# Altium schematic sheet MISC.SchDoc(MISC)
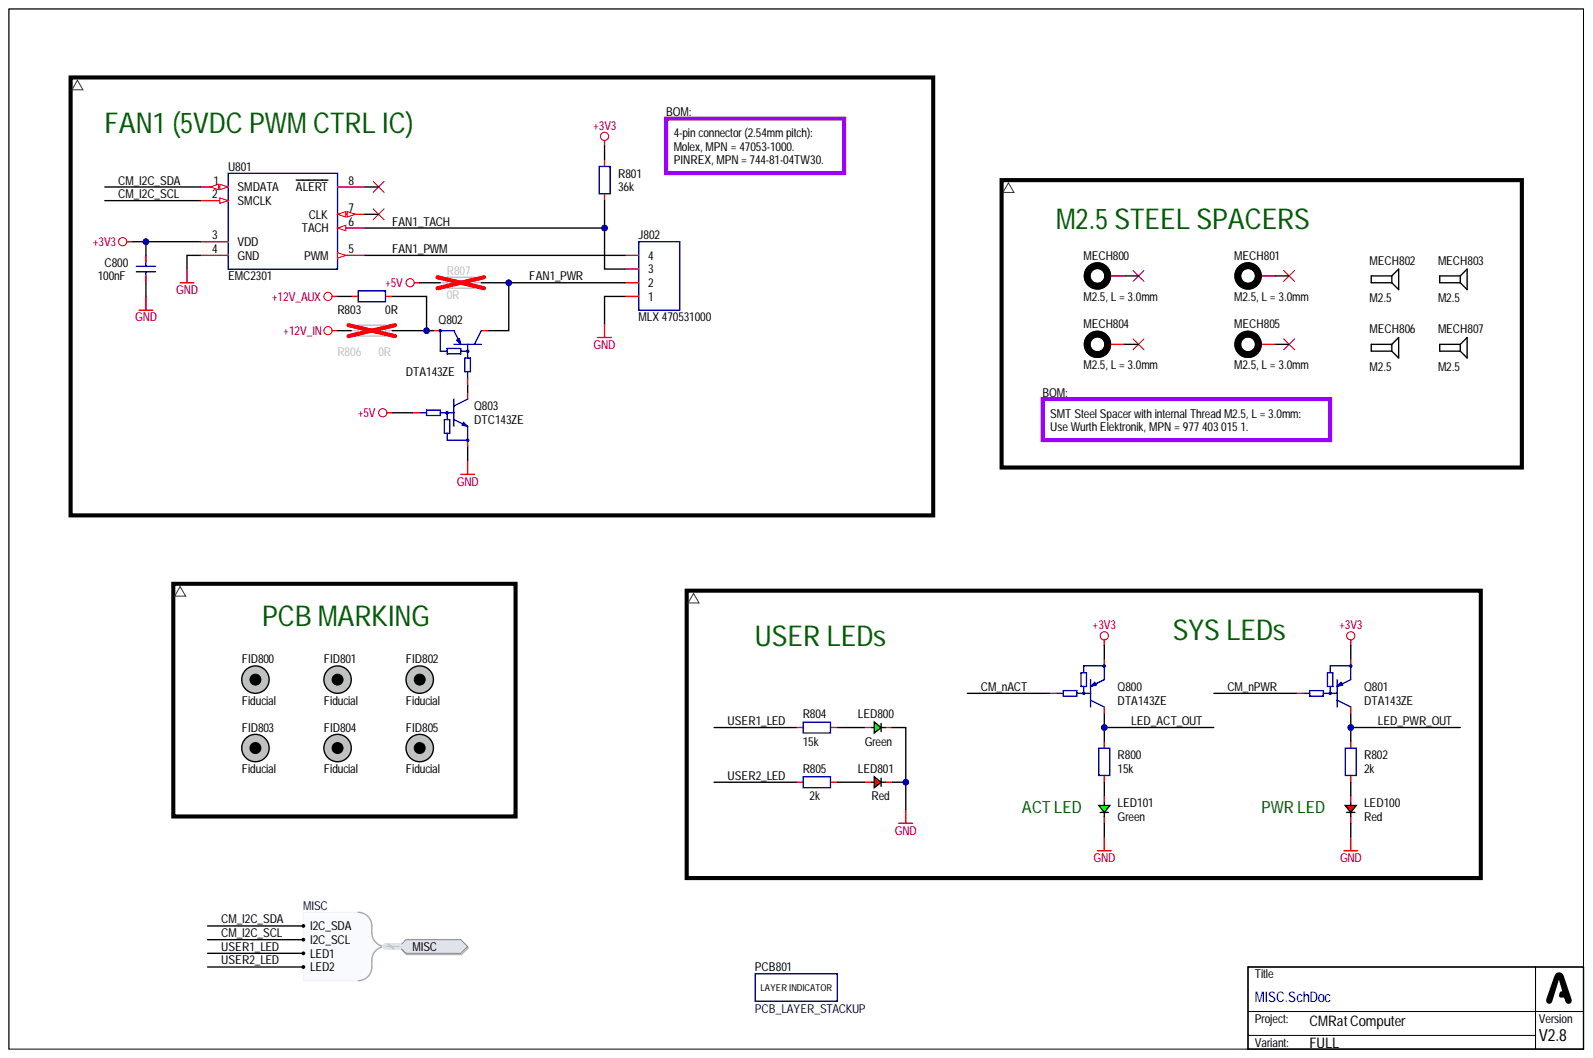
[diagram p0: MISC.SchDoc]

## Parts
C800  100nF  [CAP_0603_R]
FID800  Fiducial  [PCB_FID_RND_100x300]  (no BOM)
FID801  Fiducial  [PCB_FID_RND_100x300]  (no BOM)
FID802  Fiducial  [PCB_FID_RND_100x300]  (no BOM)
FID803  Fiducial  [PCB_FID_RND_100x300]  (no BOM)
FID804  Fiducial  [PCB_FID_RND_100x300]  (no BOM)
FID805  Fiducial  [PCB_FID_RND_100x300]  (no BOM)
J802  MLX 470531000  MPN: 470531000  [CON_MLX_470531000]
LED100  Red  Component Type: LED  [LED_0805_RED_R]
LED101  Green  Component Type: LED  [LED_0805_GRN_R]
LED800  Green  [LED_0805_GRN_R]
LED801  Red  [LED_0805_RED_R]
MECH800  M2.5, L = 3.0mm  [PCB_WRT_9774030151]
MECH801  M2.5, L = 3.0mm  [PCB_WRT_9774030151]
MECH802  M2.5  (mechanical)
MECH803  M2.5  (mechanical)
MECH804  M2.5, L = 3.0mm  [PCB_WRT_9774030151]
MECH805  M2.5, L = 3.0mm  [PCB_WRT_9774030151]
MECH806  M2.5  (mechanical)
MECH807  M2.5  (mechanical)
PCB801  PCB_LAYER_STACKUP  [PCB_STACKUP-4L_A2]  (no BOM)
Q800  DTA143ZE  [PKG_SOT523_SC75_SOT416_P100_R]
Q801  DTA143ZE  [PKG_SOT523_SC75_SOT416_P100_R]
Q802  DTA143ZE  [PKG_SOT523_SC75_SOT416_P100_R]
Q803  DTC143ZE  [PKG_SOT523_SC75_SOT416_P100_R]
R800  15k  [RES_0603_R]
R801  36k  [RES_0603_R]
R802  2k  [RES_0603_R]
R803  0R  [RES_0603_R]
R804  15k  [RES_0603_R]
R805  2k  [RES_0603_R]
R806  0R  [RES_0603_R]
R807  0R  [RES_0603_R]
TB800  =Value  (no BOM)
U801  EMC2301  [ICC_TSSOP8_300X300X110P65]

## Ports
MISC, MISC.I2C_SCL, MISC.I2C_SDA, MISC.LED1, MISC.LED2

## Nets
+3V3: C800.2 Q800.2 Q801.2 R801.2 U801.3(VDD)
+5V: Q803.1 R807.2
+12V_AUX: R803.2
+12V_IN: R806.2
GND: C800.1 J802.1 LED100.1 LED101.1 LED800.1 LED801.1 Q803.2 U801.4(GND)
CM_I2C_SCL: U801.2(SMCLK) ; port MISC
CM_I2C_SDA: U801.1(SMDATA) ; port MISC
CM_nACT: Q800.1
CM_nPWR: Q801.1
FAN1_PWM: J802.4 U801.5(PWM)
FAN1_PWR: J802.2 Q802.3 R807.1
FAN1_TACH: J802.3 R801.1 U801.6(TACH)
LED_ACT_OUT: Q800.3 R800.2
LED_PWR_OUT: Q801.3 R802.2
USER1_LED: R804.1 ; port MISC
USER2_LED: R805.1 ; port MISC
NetLED100_2: LED100.2 R802.1
NetLED101_2: LED101.2 R800.1
NetLED800_2: LED800.2 R804.2
NetLED801_2: LED801.2 R805.2
NetQ802_1: Q802.1 Q803.3
NetQ802_2: Q802.2 R803.1 R806.1

Not connected: MECH800 1; MECH801 1; MECH804 1; MECH805 1; U801 7(CLK) 8(ALERT)

## Library data of the parts
C800 = CAP-0008: Capacitor; MLCC; 100nF; 10V X5R; Manufacturer: YAGEO; MPN: CC0603KRX7R9BB104; Database Table Name: CAPACITOR$; Package (Case): 0603; Component Kind: Standard; Component Subtype: MLCC; Component Type: Capacitor; Mounting Type: SMD; Value: 100nF; Library Name: MirFol_db_local.DbLib
FID800-FID805 = PCB_FID_RND: PCB Marking; Fiducial; Round;; Component Type: Fiducial; Value: Fiducial; Library Name: MirFol_lib.SchLib
J802 = CON_1X04_COL: Connector; 1 Row; 470531000; Manufacturer: Molex; Component Subtype: Pin header; Package (Case): THT; Component Kind: Standard; Component Type: Connector; Mounting Type: THT; Value: MLX 470531000; Library Name: MirFol_lib.SchLib
LED100 LED801 = DIO_LED_RED: Diode; LED; Red;; Manufacturer: Foshan NationStar Optoelectronics; MPN: NCD0805R1; Component Subtype: LED Diode; Package (Case): 0805; Component Kind: Standard; Mounting Type: SMD; Value: Red; Library Name: MirFol_lib.SchLib
  LED801: Component Type: LED
LED101 LED800 = DIO_LED_GRN: Diode; LED; Green;; Manufacturer: Foshan NationStar Optoelectronics; MPN: NCD0805G1; Component Subtype: LED Diode; Package (Case): 0805; Component Kind: Standard; Mounting Type: SMD; Value: Green; Library Name: MirFol_lib.SchLib
  LED800: Component Type: LED
MECH800 MECH801 MECH804 MECH805 = PCB_MECH_WRT_WA-SMSI: PCB; Mechanical; SMT Steel Spacer; M2.5;; Manufacturer: Wurth Elektronik; MPN: 977 403 015 1; Component Subtype: SMD Spacer; Package (Case): SMD; Component Kind: Standard; Component Type: Spacer (Standoff); Mounting Type: SMD; Value: M2.5, L = 3.0mm; Library Name: MirFol_lib.SchLib
MECH802 MECH803 MECH806 MECH807 = PCB_MECH_SCR: Mechnical; Screw;; MPN: M2.5 screw; Value: M2.5; Library Name: MirFol_lib.SchLib
Q800-Q802 = TRA_BJT_PNP_DIG_ONS_DTA143ZE: Transistor; BJT; PNP; Digital;; Manufacturer: On Semiconductor; Component Subtype: PNPTransistor; Package (Case): SOT-523; Component Kind: Standard; Component Type: Transistor; Library Name: AN_lib.SchLib; Mounting Type: SMD; MPN: DTA143ZE; Value: DTA143ZE
Q803 = TRA_BJT_NPN_DIG_BEC: Transistor; BJT; NPN; Digital;; Manufacturer: On Semiconductor; Component Subtype: NPN Transistor; Package (Case): SOT-523; Component Kind: Standard; Component Type: Transistor; Mounting Type: SMD; MPN: DTC143ZE; Value: DTC143ZE; Library Name: MirFol_lib.SchLib
R800 R804 = RES-0043: Resistor; Thick Film; 15k; 1%; 100mW; 100PPM; 75V; Standard; SMD; 0603 (1608);; Manufacturer: UNI-ROYAL(Uniroyal Elec); MPN: 0603WAF1502T5E; Database Table Name: RESISTOR$; Package (Case): 0603; Component Kind: Standard; Component Subtype: Thick Film; Component Type: Resistor; Mounting Type: SMD; Value: 15k; Library Name: MirFol_db_local.DbLib
R801 = RES-0054: Resistor; Thick Film; 36k; SMD; 0603 (1608);; Manufacturer: UNI-ROYAL(Uniroyal Elec); MPN: 0603WAF3602T5E; Database Table Name: RESISTOR$; Package (Case): 0603; Component Kind: Standard; Component Subtype: Thick Film; Component Type: Resistor; Mounting Type: SMD; Value: 36k; Library Name: MirFol_db_local.DbLib
R802 R805 = RES-0033: Resistor; Thick Film; 2k2; 1%; 100mW; 100PPM; 75V; Standard; SMD; 0603 (1608);; Manufacturer: UNI-ROYAL(Uniroyal Elec); MPN: 0603WAF2001T5E; Database Table Name: RESISTOR$; Package (Case): 0603; Component Kind: Standard; Component Subtype: Thick Film; Component Type: Resistor; Mounting Type: SMD; Value: 2k; Library Name: MirFol_db_local.DbLib
R803 R806 R807 = RES-0054: Resistor; Thick Film; 0R; SMD; 0603 (1608);; Manufacturer: UNI-ROYAL(Uniroyal Elec); MPN: 0603WAF0000T5E; Database Table Name: RESISTOR$; Package (Case): 0603; Component Kind: Standard; Component Subtype: Thick Film; Component Type: Resistor; Mounting Type: SMD; Value: 0R; Library Name: MirFol_db_local.DbLib
TB800 = SCH_TITLE_BLOCK_SIMPLE_NODATE: Library Name: Symbols_NO_BOM.SchLib
U801 = ICC_MCP_EMC2301_MSOP-8: IC; Fan controller;; Manufacturer: Microchip Technology; MPN: EMC2301-1-ACZL-TR; Package (Case): MSOP-8; Component Kind: Standard; Component Subtype: Fan controller; Component Type: IC; Mounting Type: SMD; Value: EMC2301; Library Name: MirFol_lib.SchLib
PCB801 = PCB_LAYER_STACKUP: Component Type: PCB Marking; Library Name: MirFol_lib.SchLib
